# Altium schematic sheet Sensor Package.SchDoc("Sensor Package")
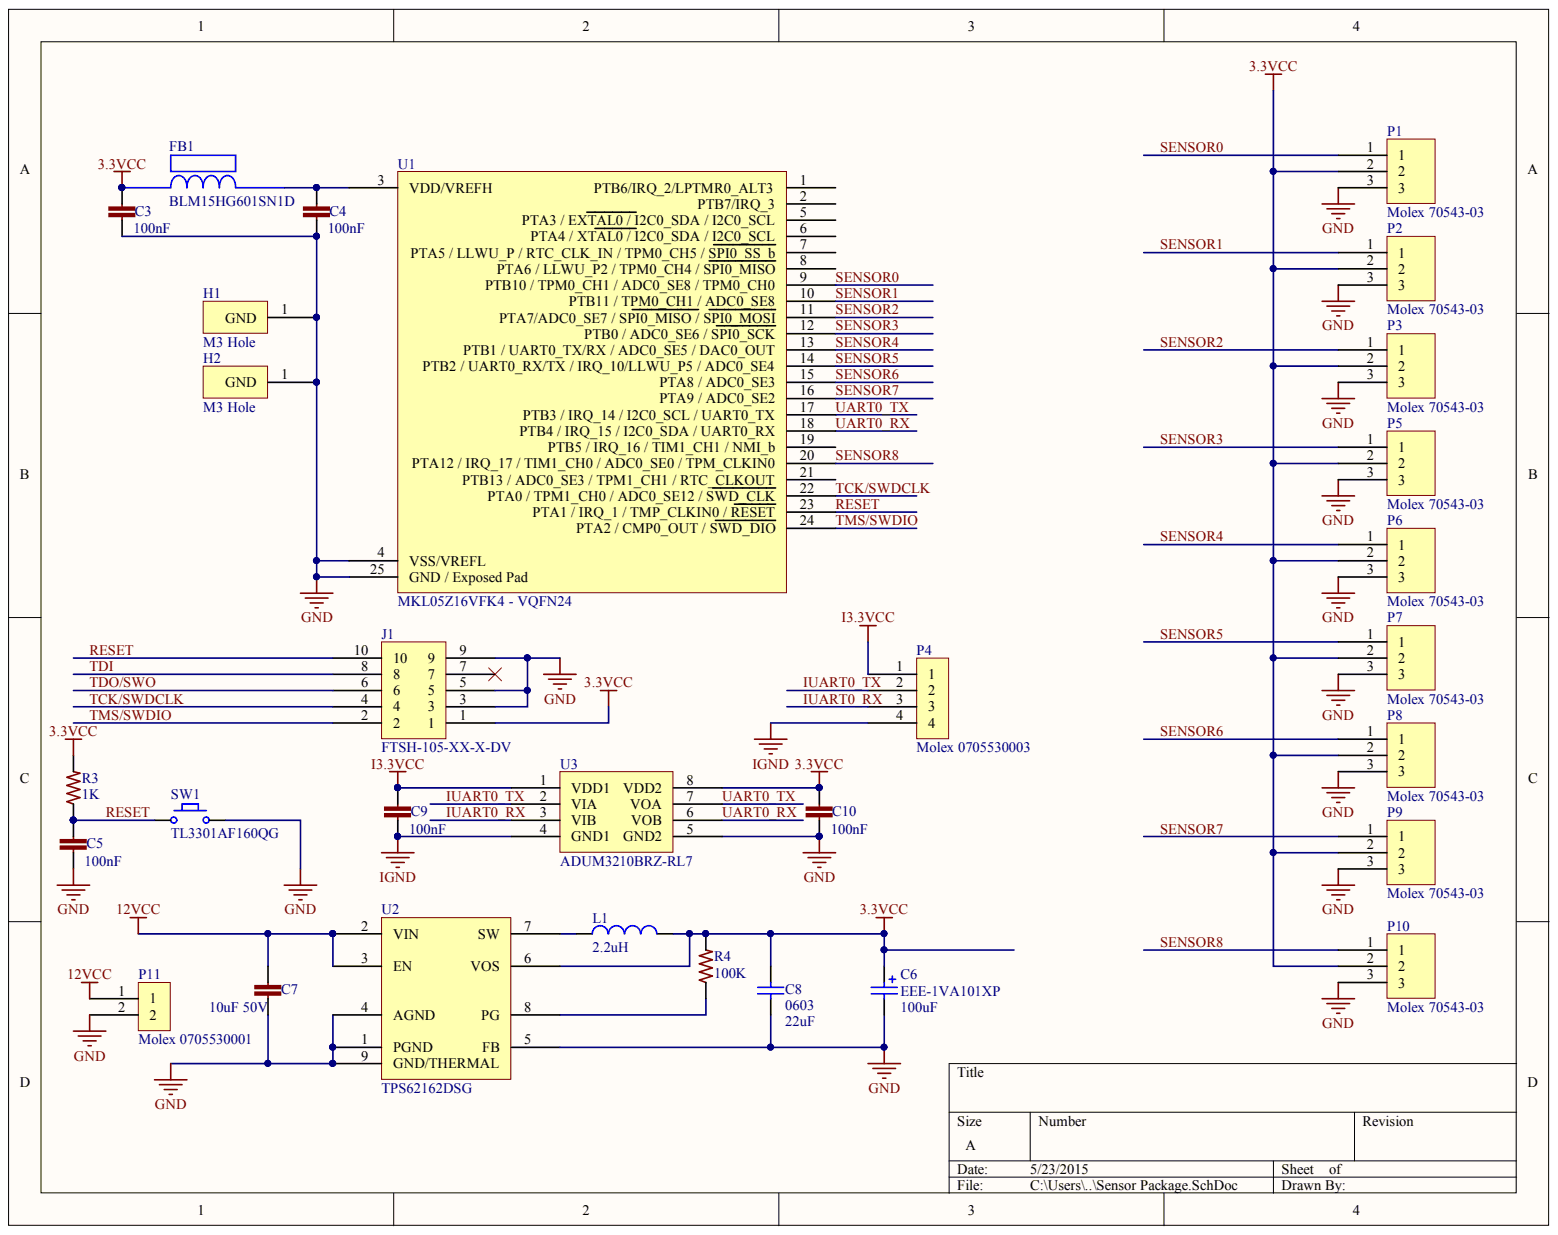
[diagram p0: Sensor Package.SchDoc]

## Parts
C3  100nF  [C0402]
C4  100nF  [C0402]
C5  100nF  [C0402]
C6  EEE-1VA101XP  value 100uF  [EEE-1VA101XP]
C7  10uF 50V  [C1206]
C8  0603  value 22uF  [C0805]
C9  100nF  [C0402]
C10  100nF  [C0402]
FB1  BLM15HG601SN1D  [BLM18PG121SN1D]
H1  M3 Hole  [Hole-3mm]
H2  M3 Hole  [Hole-3mm]
J1  FTSH-105-XX-X-DV  [FTSH-105-XX-X-DV]
L1  value 2.2uH  [VLS252012T-2R2M1R3]  (hidden comment: VLS252012T-2R2M1R3)
P1  Molex 70543-03  [70543-03]
P2  Molex 70543-03  [70543-03]
P3  Molex 70543-03  [70543-03]
P4  Molex 0705530003  MFR: Molex  [0705530003]
P5  Molex 70543-03  [70543-03]
P6  Molex 70543-03  [70543-03]
P7  Molex 70543-03  [70543-03]
P8  Molex 70543-03  [70543-03]
P9  Molex 70543-03  [70543-03]
P10  Molex 70543-03  [70543-03]
P11  Molex 0705530001  MFR: Molex  [0705530001]
R3  1K  [R0603]
R4  100K  [R0603]
SW1  TL3301AF160QG  [TL3301AF160QG]
U1  MKL05Z16VFK4 - VQFN24  [98ASA00474D]
U2  TPS62162DSG  [DSG8-1600X900TP]
U3  ADUM3210BRZ-RL7  [ADUM3210BRZ-RL7]

## Nets
3.3VCC: C3.1 C6.1 C8.2 C10.1 FB1.1 J1.1 L1.2 P1.2 P2.2 P3.2 P5.2 P6.2 P7.2 P8.2 P9.2 P10.2 R3.2 R4.2 U2.6(VOS) U3.8(VDD2)
12VCC: C7.1 P11.1 U2.2(VIN) U2.3(EN)
GND: C3.2 C4.2 C5.2 C6.2 C7.2 C8.1 C10.2 H1.1 H2.1 J1.3 J1.5 J1.9 P1.3 P2.3 P3.3 P5.3 P6.3 P7.3 P8.3 P9.3 P10.3 P11.2 SW1.2 U1.4(VSS/VREFL) U1.25(GND_/_Exposed_Pad) U2.1(PGND) U2.4(AGND) U2.5(FB) U2.9(GND/THERMAL) U3.5(GND2)
I3.3VCC: C9.1 P4.1 U3.1(VDD1)
IGND: C9.2 P4.4 U3.4(GND1)
IUART0_RX: P4.3 U3.3(VIB)
IUART0_TX: P4.2 U3.2(VIA)
RESET: C5.1 J1.10 R3.1 SW1.1 U1.23(PTA1_/_IRQ_1_/_TMP_CLKIN0_/_RESET)
SENSOR0: P1.1 U1.9(PTB10_/_TPM0_CH1_/_ADC0_SE8_/_TPM0_CH0)
SENSOR1: P2.1 U1.10(PTB11_/_TPM0_CH1_/_ADC0_SE8)
SENSOR2: P3.1 U1.11(PTA7/ADC0_SE7_/_SPI0_MISO_/_SPI0_MOSI)
SENSOR3: P5.1 U1.12(PTB0_/_ADC0_SE6_/_SPI0_SCK)
SENSOR4: P6.1 U1.13(PTB1_/_UART0_TX/RX_/_ADC0_SE5_/_DAC0_OUT)
SENSOR5: P7.1 U1.14(PTB2_/_UART0_RX/TX_/_IRQ_10/LLWU_P5_/_ADC0_SE4)
SENSOR6: P8.1 U1.15(PTA8_/_ADC0_SE3)
SENSOR7: P9.1 U1.16(PTA9_/_ADC0_SE2)
SENSOR8: P10.1 U1.20(PTA12_/_IRQ_17_/_TIM1_CH0_/_ADC0_SE0_/_TPM_CLKIN0)
TCK/SWDCLK: J1.4 U1.22(PTA0_/_TPM1_CH0_/_ADC0_SE12_/_SWD_CLK)
TDI: J1.8
TDO/SWO: J1.6
TMS/SWDIO: J1.2 U1.24(PTA2_/_CMP0_OUT_/_SWD_DIO)
UART0_RX: U1.18(PTB4_/_IRQ_15_/_I2C0_SDA_/_UART0_RX) U3.6(VOB)
UART0_TX: U1.17(PTB3_/_IRQ_14_/_I2C0_SCL_/_UART0_TX) U3.7(VOA)
NetC4_1: C4.1 FB1.2 U1.3(VDD/VREFH)
NetL1_1: L1.1 U2.7(SW)
NetR4_1: R4.1 U2.8(PG)

Not connected: J1 7; U1 1(PTB6/IRQ_2/LPTMR0_ALT3) 2(PTB7/IRQ_3) 5(PTA3_/_EXTAL0_/_I2C0_SDA_/_I2C0_SCL) 6(PTA4_/_XTAL0_/_I2C0_SDA_/_I2C0_SCL) 7(PTA5_/_LLWU_P_/_RTC_CLK_IN_/_TPM0_CH5_/_SPI0_SS_b) 8(PTA6_/_LLWU_P2_/_TPM0_CH4_/_SPI0_MISO) 19(PTB5_/_IRQ_16_/_TIM1_CH1_/_NMI_b) 21(PTB13_/_ADC0_SE3_/_TPM1_CH1_/_RTC_CLKOUT)

## Library data of the parts
C3-C5 C9 C10 = GRM155R71C104KA88D: CAP CER 0402 100nF 16V 10% X7R; LastRevisionNote: ImportComponents; Component Kind: Standard; LastRevisionDate: 23-Apr-2014; Library Name: 3Delight.SCHLIB; ManufacturerPartNumber: GRM155R71C104KA88D; Published: 23-Apr-2014; Signal Integrity: Model Name; Simulation: Model Name; VALUE: 100nF; Publisher: UltiMachine
C6 = EEE-1VA101XP: CAP ALUM 100UF 35V 20% SMD; Manufacture: Panasonic; Component Kind: Standard; Datasheet: http://industrial.panasonic.com/www-cgi/jvcr13pz.cgi?E+PZ+3+ABA0002+EEE1VA101XP+7+WW; Digikey Part #: PCE3951CT-ND; Height: 7.70mm; Library Name: Tigershark3D.SchLib; Manufacture Part #: EEE-1VA101XP; Mount Type: SMT Panasonic VS D Series; Price: $0.63; Signal Integrity: Cap Pol; Simulation: CAP; Status: Active
C7 = CL31A106KBHNNNE: CAP CER 10UF 50V 10% X5R 1206; Manufacturer: Samsung; LastRevisionNote: ImportComponents; Component Kind: Standard; LastRevisionDate: 25-mar-2015; Library Name: Tigershark3D.SchLib; ManufacturerPartNumber: CL31A106KBHNNNE; Published: 25-mar-2015; Signal Integrity: Model Name; Simulation: Model Name; VALUE: 10uF 50V; Publisher: UltiMachine
C8 = CL21A226KQQNNNE: CAP CER 22UF 6.3V 10% X5R 0805; MFR: Samsung; Voltage: 6.3VDC; Component Kind: Standard; Component Type: Chip Capacitor; Datasheet: http://www.digikey.com/product-detail/en/CL21A226KQQNNNE/1276-2907-2-ND/3888565; Digikey #: 1276-2907-2-ND; Height: 1mm; LatestRevisionDate: April 2015; Library Name: Sensor Package.SchLib; MFR Part #: CL21A226KQQNNNE; Mounting Type: SMT 0805; PackageReference: 0805; Part Description: CAP CER 22UF 6.3V 10% X5R 0805; Published: April 2015; Signal Integrity: Cap Semi; Simulation: CAP; Tolerance: 10%
H1 H2 = Hole: 3mm Hole; Component Kind: Standard; Library Name: Sensor Package.SchLib
J1 = ARM Cortex JTAG-DEBUG Header: Component Kind: Standard; Library Name: Adams Altium Library.SchLib
L1 = VLS252012T-2R2M1R3: MFR: TDK Corporation; Component Kind: Standard; Description: VLS252012T-2R2M1R3; LatestRevisionDate: April 2015; LatestRevisionNote: IPC-7351 Footprint Added.; Library Name: Sensor Package.SchLib; MFR#: VLS252012T-2R2M1R3; PackageDescription: Wire Wound Inductor Package; PackageReference: Custom Wound INductor; Published: April 2015; Signal Integrity: Inductor; Publisher: Adam Vadala-Roth
P1-P3 P5-P10 = Molex Endstop: Component Kind: Standard; Library Name: RADMBo Schematic Library.SchLib
P4 = 0705530003: Molex 0705530003 Right Angle 2.54mm Shrouded Header; Component Kind: Standard; Digikey #: WM4902-ND; Library Name: Sensor Package.SchLib; MFR#: 0705530003; Type: 2.54mm shrouded 4 pin right angle throughhole connector
P11 = 0705530001: Molex 0705530002 Right Angle 2.54mm Shrouded Header; Component Kind: Standard; Digikey #: WM4900-ND; Library Name: Sensor Package.SchLib; MFR#: 0705530001; Type: 2.54mm shrouded 2 pin right angle throughhole connector
R3 = ERJ-3EKF1001V: RES 0603 1K 100mW 1%; LastRevisionNote: ImportComponents; Component Kind: Standard; LastRevisionDate: 23-Apr-2014; Library Name: 3Delight.SCHLIB; ManufacturerPartNumber: ERJ-3EKF1001V; Published: 23-Apr-2014; Signal Integrity: Model Name; Simulation: Model Name; VALUE: 1K; Publisher: UltiMachine
R4 = RC0603FR-07100KL: RES 100K OHM 1/10W 1% 0603 SMD; LastRevisionNote: ImportComponents; Component Kind: Standard; LastRevisionDate: 23-Apr-2014; Library Name: 3Delight.SCHLIB; ManufacturerPartNumber: RC0603FR-07100KL; Published: 23-Apr-2014; Signal Integrity: Model Name; Simulation: Model Name; VALUE: 100K; Publisher: UltiMachine
U1 = MKL05Z16VFK4 - 24 Pin VQFN: ARM Cortex M0+; Component Kind: Standard; Library Name: Encoder Fin.SchLib
U2 = TPS62162SOP: Component Kind: Standard; Library Name: Adams Altium Library.SchLib
U3 = ADUM3210BRZ-RL7: DGTL ISO 2.5KV GEN PURP 8SOIC; MFR: Analog Devices; RHoS?: yes; Component Kind: Standard; Digikey #: ADUM3210BRZ-RL7CT-ND; Library Name: Sensor Package.SchLib; MFR#: ADUM3210BRZ-RL7; Revision Date: April 2015; Type: 8 pin SOIC
FB1 = BLM15HG601SN1D: Component Kind: Standard; Description: BLM15HG601SN1D; Library Name: AHD Part Library.SchLib
SW1 = TL3301AF160QG: Component Kind: Standard; Description: TL3301AF160QG; MFR#: TL3301AF160QG; Type: SMD Momentary Tactile Switch; Library Name: MongoosMini.SchLib
